# Altium schematic sheet ECU.SchDoc
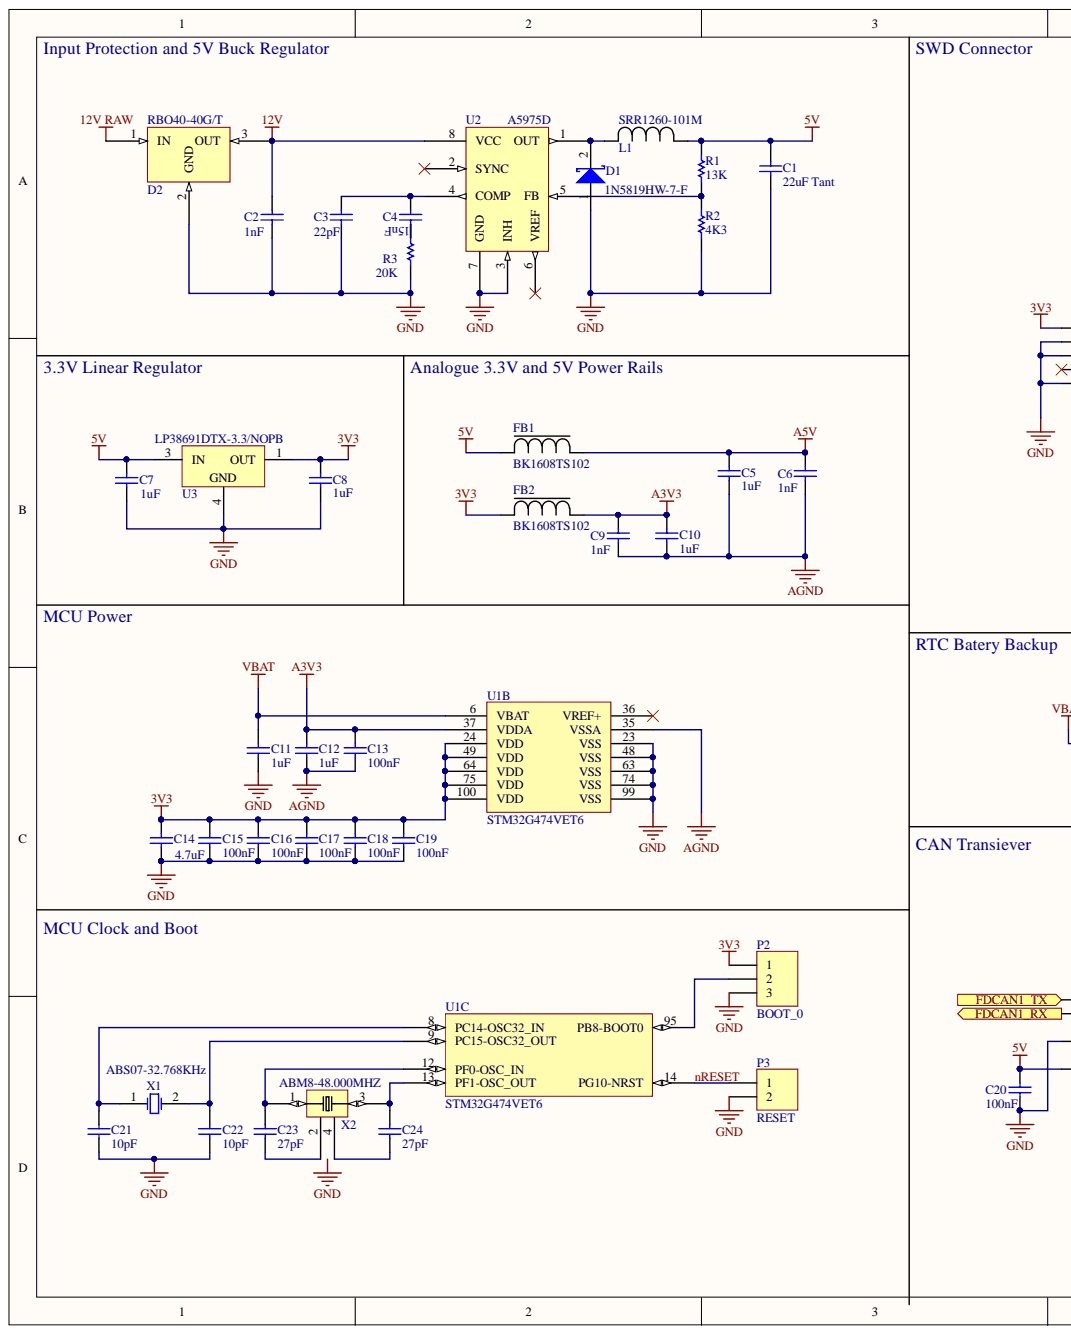
[diagram p0: ECU.SchDoc, left]
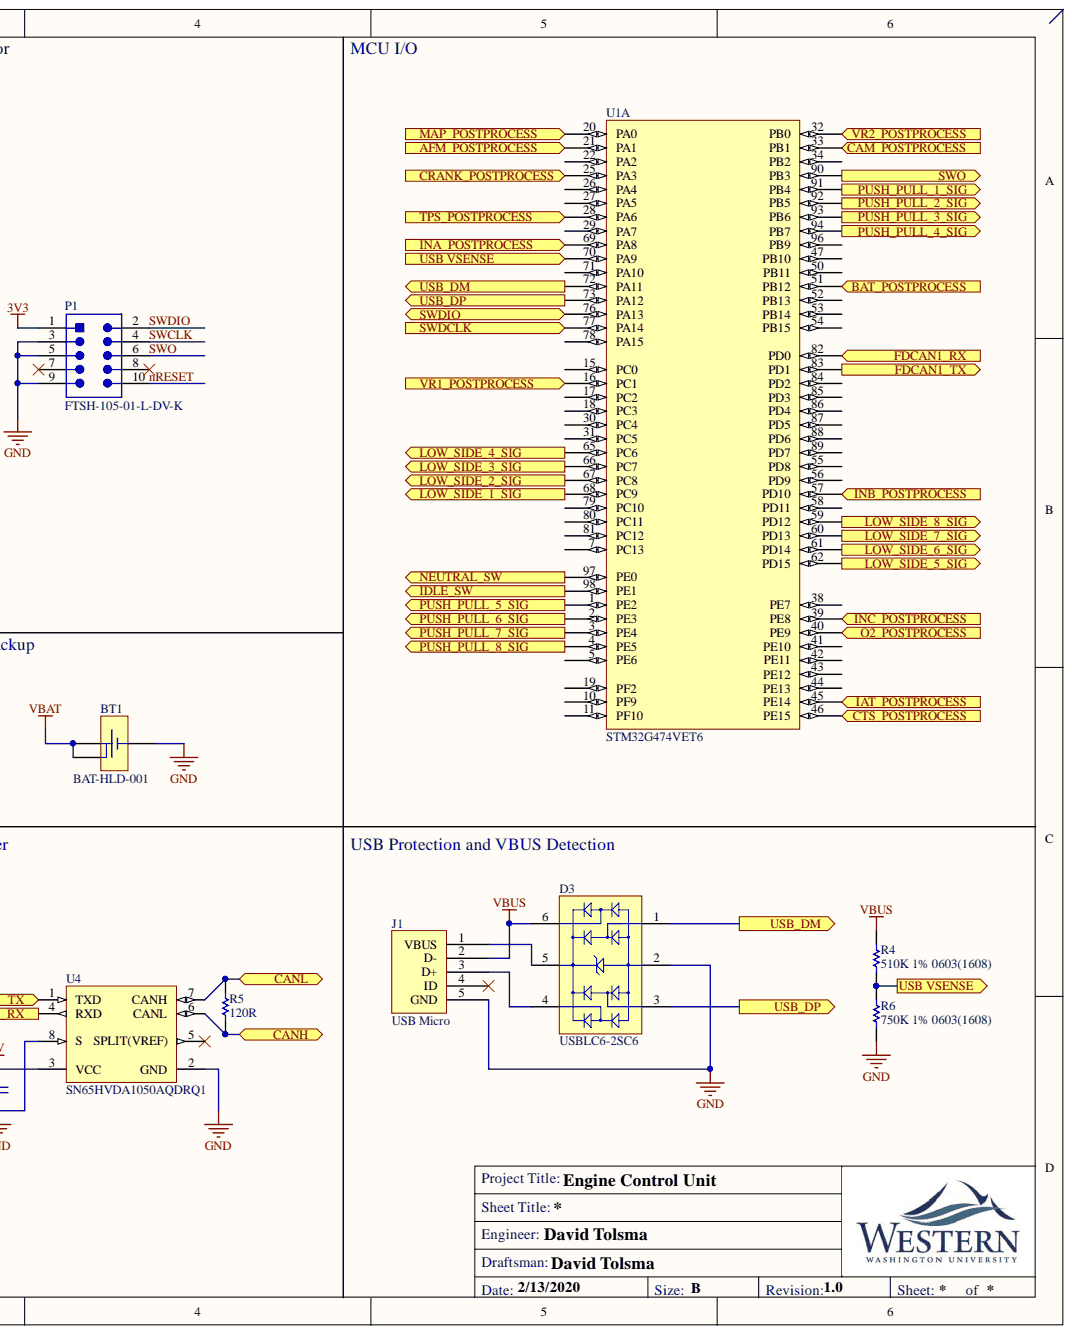
[diagram p1: ECU.SchDoc, right]

## Parts
BT1  BAT-HLD-001  [BATHLD-001]
C1  22uF Tant  value 22uF  [CAPC1210(3225)280_L]
C2  1nF  [CAPC0603(1608)100_N]
C3  22pF  [CAPC0603(1608)100_M]
C4  15nF  [CAPC0603(1608)100_L]
C5  1uF  [CAPC0603(1608)100_M]
C6  1nF  [CAPC0603(1608)100_N]
C7  1uF  [CAPC0603(1608)100_L]
C8  1uF  [CAPC0603(1608)100_L]
C9  1nF  [CAPC0603(1608)100_N]
C10  1uF  [CAPC0603(1608)100_M]
C11  1uF  [CAPC0603(1608)100_M]
C12  1uF  [CAPC0603(1608)100_M]
C13  100nF  [CAPC0603(1608)100_M]
C14  4.7uF  [CAPC0603(1608)55_N]
C15  100nF  [CAPC0603(1608)100_M]
C16  100nF  [CAPC0603(1608)100_M]
C17  100nF  [CAPC0603(1608)100_M]
C18  100nF  [CAPC0603(1608)100_M]
C19  100nF  [CAPC0603(1608)100_M]
C20  100nF  [CAPC0603(1608)100_M]
C21  10pF  [CAPC0603(1608)100_L]
C22  10pF  [CAPC0603(1608)100_L]
C23  27pF  [CAPC0603(1608)100_M]
C24  27pF  [CAPC0603(1608)100_M]
D1  1N5819HW-7-F  [DIOD-SOD-123-2_V]
D2  RBO40-40G/T  [D2PAK-L]
D3  USBLC6-2SC6  [STM-SOT23-6L_V]
FB1  BK1608TS102  [BK1608TS102-T]
FB2  BK1608TS102  [BK1608TS102-T]
J1  USB Micro  [USB_CONN]
L1  SRR1260-101M  [IND_BOURNS_SRR1260-101M]
P1  FTSH-105-01-L-DV-K  [SMTC-FTSH-105-01-L-DV-K_V]
P2  BOOT_0  [HDR1X3]
P3  RESET  [HDR1X2]
R1  13K  [RESC0603(1608)_L]
R2  4K3  [RESC0603(1608)_M]
R3  20K  [RESC0603(1608)_L]
R4  510K 1% 0603(1608)  [RESC0603(1608)_N]
R5  120R  [RESC0603(1608)_L]
R6  750K 1% 0603(1608)  [RESC0603(1608)_M]
U1  STM32G474VET6  [STM32G474VET6]  (parts A,B,C)
U2  A5975D  [A5975D_Medium_Density]
U3  LP38691DTX-3.3/NOPB  [TD03B_N]
U4  SN65HVDA1050AQDRQ1  [D8_L]
X1  ABS07-32.768KHz  [ABRA-ABS07-2_V]
X2  ABM8-48.000MHZ  [ABM8-48.000MHZ-10-1-U-T]

## Ports
AFM_POSTPROCESS, BAT_POSTPROCESS, CAM_POSTPROCESS, CANH, CANL, CRANK_POSTPROCESS, CTS_POSTPROCESS, FDCAN1_RX, FDCAN1_TX, IAT_POSTPROCESS, IDLE_SW, INA_POSTPROCESS, INB_POSTPROCESS, INC_POSTPROCESS, LOW_SIDE_1_SIG, LOW_SIDE_2_SIG, LOW_SIDE_3_SIG, LOW_SIDE_4_SIG, LOW_SIDE_5_SIG, LOW_SIDE_6_SIG, LOW_SIDE_7_SIG, LOW_SIDE_8_SIG, MAP_POSTPROCESS, NEUTRAL_SW, O2_POSTPROCESS, PUSH_PULL_1_SIG, PUSH_PULL_2_SIG, PUSH_PULL_3_SIG, PUSH_PULL_4_SIG, PUSH_PULL_5_SIG, PUSH_PULL_6_SIG, PUSH_PULL_7_SIG, PUSH_PULL_8_SIG, SWDCLK, SWDIO, SWO, TPS_POSTPROCESS, USB VSENSE, USB_DM, USB_DP, VR1_POSTPROCESS, VR2_POSTPROCESS

## Nets
3V3: C8.2 C14.2 C15.2 C16.2 C17.2 C18.2 C19.2 FB2.2 P1.1 P2.1 U1.24(VDD) U1.49(VDD) U1.64(VDD) U1.75(VDD) U1.100(VDD) U3.1
5V: C1.2 C7.2 C20.2 FB1.2 L1.1 R1.2 U3.3 U4.3(VCC)
12V: C2.1 D2.3 U2.8(VCC)
12V RAW: D2.1
A3V3: C9.1 C10.2 C12.2 C13.2 FB2.1 U1.37(VDDA)
A5V: C5.2 C6.1 FB1.1
AGND: C5.1 C6.2 C9.2 C10.1 C12.1 C13.1 U1.35(VSSA)
GND: BT1.1 C1.1 C2.2 C3.1 C7.1 C8.1 C11.1 C14.1 C15.1 C16.1 C17.1 C18.1 C19.1 C20.1 C21.1 C22.1 D1.1 D2.2 D3.2 J1.5(GND) P1.3 P1.5 P1.9 P2.3 P3.2 R2.2 R3.1 R6.1 U1.23(VSS) U1.48(VSS) U1.63(VSS) U1.74(VSS) U1.99(VSS) U2.3(INH) U2.7(GND) U3.4 U4.2(GND) U4.8(S)
VBAT: BT1.2 BT1.3 C11.2 U1.6(VBAT)
VBUS: D3.6 J1.2(D-) R4.2
AFM_POSTPROCESS: U1.21(PA1) ; port AFM_POSTPROCESS
BAT_POSTPROCESS: U1.51(PB12) ; port BAT_POSTPROCESS
CAM_POSTPROCESS: U1.33(PB1) ; port CAM_POSTPROCESS
CANH: R5.1 U4.6(CANL) ; port CANH
CANL: R5.2 U4.7(CANH) ; port CANL
CRANK_POSTPROCESS: U1.25(PA3) ; port CRANK_POSTPROCESS
CTS_POSTPROCESS: U1.46(PE15) ; port CTS_POSTPROCESS
FDCAN1_RX: U1.82(PD0) U4.4(RXD) ; port FDCAN1_RX
FDCAN1_TX: U1.83(PD1) U4.1(TXD) ; port FDCAN1_TX
IAT_POSTPROCESS: U1.45(PE14) ; port IAT_POSTPROCESS
IDLE_SW: U1.98(PE1) ; port IDLE_SW
INA_POSTPROCESS: U1.69(PA8) ; port INA_POSTPROCESS
INB_POSTPROCESS: U1.57(PD10) ; port INB_POSTPROCESS
INC_POSTPROCESS: U1.39(PE8) ; port INC_POSTPROCESS
LOW_SIDE_1_SIG: U1.68(PC9) ; port LOW_SIDE_1_SIG
LOW_SIDE_2_SIG: U1.67(PC8) ; port LOW_SIDE_2_SIG
LOW_SIDE_3_SIG: U1.66(PC7) ; port LOW_SIDE_3_SIG
LOW_SIDE_4_SIG: U1.65(PC6) ; port LOW_SIDE_4_SIG
LOW_SIDE_5_SIG: U1.62(PD15) ; port LOW_SIDE_5_SIG
LOW_SIDE_6_SIG: U1.61(PD14) ; port LOW_SIDE_6_SIG
LOW_SIDE_7_SIG: U1.60(PD13) ; port LOW_SIDE_7_SIG
LOW_SIDE_8_SIG: U1.59(PD12) ; port LOW_SIDE_8_SIG
MAP_POSTPROCESS: U1.20(PA0) ; port MAP_POSTPROCESS
NEUTRAL_SW: U1.97(PE0) ; port NEUTRAL_SW
nRESET: P1.10 P3.1 U1.14(PG10-NRST)
O2_POSTPROCESS: U1.40(PE9) ; port O2_POSTPROCESS
PUSH_PULL_1_SIG: U1.91(PB4) ; port PUSH_PULL_1_SIG
PUSH_PULL_2_SIG: U1.92(PB5) ; port PUSH_PULL_2_SIG
PUSH_PULL_3_SIG: U1.93(PB6) ; port PUSH_PULL_3_SIG
PUSH_PULL_4_SIG: U1.94(PB7) ; port PUSH_PULL_4_SIG
PUSH_PULL_5_SIG: U1.1(PE2) ; port PUSH_PULL_5_SIG
PUSH_PULL_6_SIG: U1.2(PE3) ; port PUSH_PULL_6_SIG
PUSH_PULL_7_SIG: U1.3(PE4) ; port PUSH_PULL_7_SIG
PUSH_PULL_8_SIG: U1.4(PE5) ; port PUSH_PULL_8_SIG
SWCLK: P1.4
SWDCLK: U1.77(PA14) ; port SWDCLK
SWDIO: P1.2
SWO: P1.6
TPS_POSTPROCESS: U1.28(PA6) ; port TPS_POSTPROCESS
USB VSENSE: R4.1 R6.2 U1.70(PA9) ; port USB VSENSE
USB_DM: D3.1 U1.72(PA11) ; port USB_DM
USB_DP: D3.3 U1.73(PA12) ; port USB_DP
VR1_POSTPROCESS: U1.16(PC1) ; port VR1_POSTPROCESS
VR2_POSTPROCESS: U1.32(PB0) ; port VR2_POSTPROCESS
NetC3_2: C3.2 C4.2 U2.4(COMP)
NetC4_1: C4.1 R3.2
NetC21_2: C21.2 U1.8(PC14-OSC32_IN) X1.1
NetC22_2: C22.2 U1.9(PC15-OSC32_OUT) X1.2
NetC23_1: C23.1 X2.2
NetC23_2: C23.2 U1.12(PF0-OSC_IN) X2.1
NetC24_1: C24.1 X2.4
NetC24_2: C24.2 U1.13(PF1-OSC_OUT) X2.3
NetD1_2: D1.2 L1.2 U2.1(OUT)
NetD3_4: D3.4 J1.3(D+)
NetD3_5: D3.5 J1.1(VBUS)
NetP2_2: P2.2 U1.95(PB8-BOOT0)
NetR1_1: R1.1 R2.1 U2.5(FB)
NetU1_76: U1.76(PA13) ; port SWDIO
NetU1_90: U1.90(PB3) ; port SWO

Not connected: J1 4(ID); P1 7 8; U1 5(PE6) 7(PC13) 10(PF9) 11(PF10) 15(PC0) 17(PC2) 18(PC3) 19(PF2) 22(PA2) 26(PA4) 27(PA5) 29(PA7) 30(PC4) 31(PC5) 34(PB2) 36(VREF+) 38(PE7) 41(PE10) 42(PE11) 43(PE12) 44(PE13) 47(PB10) 50(PB11) 52(PB13) 53(PB14) 54(PB15) 55(PD8) 56(PD9) 58(PD11) 71(PA10) 78(PA15) 79(PC10) 80(PC11) 81(PC12) 84(PD2) 85(PD3) 86(PD4) 87(PD5) 88(PD6) 89(PD7) 96(PB9); U2 2(SYNC) 6(VREF); U4 5(SPLIT(VREF))

## Library data of the parts
BT1 = CMP-0168-00043-1: 20mm Coin Cell Battery Holder; PCB_Layout: Complies with manufacturer's recommendation.; Component Kind: Standard; DatasheetVersion: rev1.0, Dec-2007; Library Name: Altium Content Vault; PackageDescription: Low Profile SM Battery Holder, 20mm Lithium Cell, 21.1 x 15.3 x 4.2 mm Body; PackageReference: BATHLD-001; PackageVersion: rev1.0, Dec-2007
C1 = CMP-1038-02268-1: CAP 22uF 10V ±10% 1210 (3225 Metric) Thickness 2.8mm SMD; Case-EIA: 1210; Case-Metric: 3225; Tolerance: Â±10%; Component Kind: Standard; Library Name: Altium Content Vault; Max Thickness: 2.8 mm; Rated Voltage: 10 V; Technology: SMT
C2 C6 C9 = CMP-1035-01887-1: CAP 1nF 50V ±2% 0603 (1608 Metric) Thickness 1mm SMD; Case-EIA: 0603; Case-Metric: 1608; Tolerance: Â±2%; Component Kind: Standard; Library Name: Altium Content Vault; Max Thickness: 1 mm; Rated Voltage: 50 V; Technology: SMT; Value: 1nF
C3 = CMP-1035-00822-1: CAP 22pF 50V ±10% 0603 (1608 Metric) Thickness 1mm SMD; Case-EIA: 0603; Case-Metric: 1608; Tolerance: Â±10%; Component Kind: Standard; Library Name: Altium Content Vault; Max Thickness: 1 mm; Rated Voltage: 50 V; Technology: SMT; Value: 22pF
C4 = CMP-1035-02380-1: CAP 15nF 50V ±5% 0603 (1608 Metric) Thickness 1mm SMD; Case-EIA: 0603; Case-Metric: 1608; Tolerance: Â±5%; Component Kind: Standard; Library Name: Altium Content Vault; Max Thickness: 1 mm; Rated Voltage: 50 V; Technology: SMT; Value: 15nF
C5 C10-C12 = CMP-1035-02733-1: CAP 1uF 10V ±10% 0603 (1608 Metric) Thickness 1mm SMD; Case-EIA: 0603; Case-Metric: 1608; Tolerance: Â±10%; Component Kind: Standard; Library Name: Altium Content Vault; Max Thickness: 1 mm; Rated Voltage: 10 V; Technology: SMT; Value: 1uF
C7 C8 = CMP-1035-02745-1: CAP 1uF 50V ±10% 0603 (1608 Metric) Thickness 1mm SMD; Case-EIA: 0603; Case-Metric: 1608; Tolerance: Â±10%; Component Kind: Standard; Library Name: Altium Content Vault; Max Thickness: 1 mm; Rated Voltage: 50 V; Technology: SMT; Value: 1uF
C13 C15-C20 = CMP-1035-02580-1: CAP 100nF 10V ±10% 0603 (1608 Metric) Thickness 1mm SMD; Case-EIA: 0603; Case-Metric: 1608; Tolerance: Â±10%; Component Kind: Standard; Library Name: Altium Content Vault; Max Thickness: 1 mm; Rated Voltage: 10 V; Technology: SMT; Value: 100nF
C14 = CMP-1035-02784-1: CAP 4.7uF 10V ±10% 0603 (1608 Metric) Thickness 0.55mm SMD; Case-EIA: 0603; Case-Metric: 1608; Tolerance: Â±10%; Component Kind: Standard; Library Name: Altium Content Vault; Max Thickness: 0.55 mm; Rated Voltage: 10 V; Technology: SMT; Value: 4.7uF
C21 C22 = CMP-1035-00556-1: CAP 10pF 50V ±1% 0603 (1608 Metric) Thickness 1mm SMD; Case-EIA: 0603; Case-Metric: 1608; Tolerance: Â±1%; Component Kind: Standard; Library Name: Altium Content Vault; Max Thickness: 1 mm; Rated Voltage: 50 V; Technology: SMT; Value: 10pF
C23 C24 = CMP-1035-00886-1: CAP 27pF 50V ±1% 0603 (1608 Metric) Thickness 1mm SMD; Case-EIA: 0603; Case-Metric: 1608; Tolerance: Â±1%; Component Kind: Standard; Library Name: Altium Content Vault; Max Thickness: 1 mm; Rated Voltage: 50 V; Technology: SMT; Value: 27pF
D1 = CMP-0303-00003-1: 1.0 A Surface Mount Schottky Barrier Rectifier, 40 V, -65 to 125 degC, 2-Pin SOD-123 Package, RoHS, Tape and Reel; Manufacturer: Diodes Inc; @ IF (A): 1; @ Terminal Temperature TT (degC): 90; @ VR (V): 40; Forward Voltage Drop VF (V): 0.45; Maximum Reverse Current IR (uA): 50; Peak Forward Surge Current IFSM (A): 25; VR (RMS) (V): 28; Component Kind: Standard; ComponentLink1Description: Manufacturer URL; ComponentLink1URL: http://www.diodes.com/; ComponentLink2Description: Datasheet; ComponentLink2URL: http://www.diodes.com/datasheets/ds30217.pdf; DatasheetVersion: Rev. 15-2; Library Name: Altium Content Vault; Maximum Average Rectified Current IO (A): 1; Mounting Technology: Surface Mount; Operating and Storage Temperature Range: -65 to 125 degC; PackageDescription: 2-Pin SOD-123, Body 2.7 x 1.55 mm, Pitch 3.7 mm; PackageReference: SOD-123-2; Packing: Tape and Reel; PartNumber: 1N5819HW-7-F; Peak Repetitive Reverse Voltage VRRM (V): 40; RoHS: TRUE; Total Capacitance CT (pF): 50
D2 = RBO40-40G/T: Designed to protect against battery reversal andload dump overvoltages in automotive applica-tions, this monolithic component offers multiplefunctions in the same package.; Component Kind: Standard; Library Name: ECU.SchLib
D3 = CMP-2000-05378-1: Very low capacitance ESD protection, 3.5 pF, -40 to 125 degC, 6-Pin SOT23, RoHS, Tape and Reel; Manufacturer: STMicroelectronics; Capacitance Value: 3.5pF; Clamping Voltage: 17V; Configuration: Dual; Direction Type: Uni-Directional; Leakage Current: 0.15uA; Number of Elements: 1; Peak Pulse Current: 5A; Product Depth (mm): 1.75mm; Product Height (mm): 1.3mm; Product Length (mm): 3.05mm; Rad Hardened: No; Reverse Breakdown Voltage: 6V; Reverse Stand-off Voltage: 5V; Suppressor Type: DiodeArrays; Test Current (It): 1mA; Clamping Voltage (Max): 17V; Component Kind: Standard; Datasheet URL: http://www.st.com/st-web-ui/static/active/en/resource/technical/document/datasheet/CD00050750.pdf; Datasheet Version: Rev. 5, 10/2011; Leakage Current (Max): 0.15uA; Library Name: Altium Content Vault; Manufacturer URL: http://www.st.com/; Mounting: SurfaceMount; Mounting Style: SurfaceMount; Operating Temperature Classification: Automotive; Operating Temperature Max Deg. C: 125C; Operating Temperature Min Deg. C: -40C; Operating Temp Range: -40Cto125C; Package / Case: SOT-23; Package Description: 6-Pin Small Outline Transistor, Body 2.925 x 1.625 mm, Pitch 0.95 mm; Package Reference: SOT23-6L; Packaging: TapeandReel; Pin Count: 6; Polarity: Uni-Directional; Working Voltage: 5V; Working Voltage (Max): 5V
J1 = USB MICRO CONN SMT R/A (Amphenol): Micro USB R/A SMT CONNECTOR; Component Kind: Standard; Supplier 1: Digi-Key; Supplier Part Number 1: 609-4618-1-ND; Library Name: WWUEE_SchematicLibrary.SchLib
L1 = SRR1260-101M: Built_By: EMA_UL_Team; Type: DEV; Vendor: Bourns Electronics; Component Kind: Standard; Description: SRR1260-101M; Library Name: ECU.SchLib; Manufacturer_Part_Number: SRR1260-101M; RefDes: RefDes; Value: Value; Copyright: Copyright (C) 2018 Accelerated Designs. All rights reserved
P1 = CMP-2000-05353-1: Male Header, Pitch 1.27 mm, 2 x 5 Position, Height 6.25 mm; Manufacturer: Samtec; Connector Type: Header,Unshrouded; Mating Products: SFMC-105-L2-S-D-TR-ND  -CONN.05"SURFACE10POSSMT  FLE-105-01-G-DV-TR-ND  -CONNSKT1.27MM10POSDUALSMD  FLE-105-01-G-DV-A-P-ND  -CONNSKT1.27MM10POSDUALSMD  FLE-105-01-G-DV-A-ND  -CONNSKT1.27MM10POSDUALSMD  CLP-105-02-G-D-P-TR-ND  -CONNRCPT10POSDUAL.05"SMD  SAM1155-05-ND  -CONNRCPT10POSDUAL.05"SMD  SAM1154-05-ND  -CONNRCPT10POSDUAL.05"SMD  SAM1153-05-ND  -CONNRCPT10POSDUAL.05"SMD  SAM1226-05-ND  -CONNRCPT.05"10POSDUALSMD; Number of Positions: 10; Number of Positions Loaded: All; Other Names: Q3937619; Pitch: 0.050"(1.27mm); Product Training Modules: Board-to-BoardConnectors; Category: Connectors,Interconnects; Color: Black; Component Kind: Standard; Contact Finish: Gold; Contact Finish Thickness: 10µin(0.25µm); Contact Mating Length: 0.120"(3.05mm); Contact Type: MalePin; Datasheet URL: http://suddendocs.samtec.com/prints/ftsh-1xx-xx-xxx-dv-xxx-mkt.pdf; Datasheet Version: Rev. ET, 10/1995; Family: RectangularConnectors-Headers,MalePins; Library Name: Altium Content Vault; Manufacturer URL: http://www.samtec.com/; Mounting Type: SurfaceMount; Number of Rows: 2; Package Description: Male Header, Pitch 1.27 mm, 2 x 5 Position, Height 5.97 mm, Tail Length 4.32 mm; Package Reference: FTSH-105-01-L-DV-K; Package URL: http://suddendocs.samtec.com/prints/ftsh-1xx-xx-xxx-dv-xxx-footprint.pdf; Packaging: Tube; Row Spacing: 0.050"(1.27mm); Series: FTSH; Standard Package: 1; Termination: Solder
P2 = Header 3: Header, 3-Pin; Component Kind: Standard; LatestRevisionDate: 17-Jul-2002; LatestRevisionNote: Re-released for DXP Platform.; Library Name: Miscellaneous Connectors.IntLib; Signal Integrity: Connector; Publisher: Altium Limited
P3 = Header 2: Header, 2-Pin; Component Kind: Standard; LatestRevisionDate: 17-Jul-2002; LatestRevisionNote: Re-released for DXP Platform.; Library Name: Miscellaneous Connectors.IntLib; Signal Integrity: Connector; Publisher: Altium Limited
R1 = CMP-1012-00635-1: 13K 0.1W 1% 0603 (1608 Metric)  SMD; Case-EIA: 0603; Case-Metric: 1608; Value: 13000.00 Ohm; Component Kind: Standard; Library Name: Altium Content Vault; Power: 0.1 W; Technology: SMT; Tolerance: 1 %
R2 = CMP-1012-00581-1: 4K3 0.1W 1% 0603 (1608 Metric)  SMD; Case-EIA: 0603; Case-Metric: 1608; Value: 4300.00 Ohm; Component Kind: Standard; Library Name: Altium Content Vault; Power: 0.1 W; Technology: SMT; Tolerance: 1 %
R3 = CMP-1012-00655-1: 20K 0.1W 1% 0603 (1608 Metric)  SMD; Case-EIA: 0603; Case-Metric: 1608; Value: 20000.00 Ohm; Component Kind: Standard; Library Name: Altium Content Vault; Power: 0.1 W; Technology: SMT; Tolerance: 1 %
R4 = CMP-1012-00816-1: 510K 0.1W 1% 0603 (1608 Metric)  SMD; Case-EIA: 0603; Case-Metric: 1608; Value: 510000.00 Ohm; Component Kind: Standard; Library Name: Altium Content Vault; Power: 0.1 W; Technology: SMT; Tolerance: 1 %
R5 = CMP-1012-00052-1: 120R 0.1W 5% 0603 (1608 Metric)  SMD; Case-EIA: 0603; Case-Metric: 1608; Value: 120.00 Ohm; Component Kind: Standard; Library Name: Altium Content Vault; Power: 0.1 W; Technology: SMT; Tolerance: 5 %
R6 = CMP-1012-00835-1: 750K 0.1W 1% 0603 (1608 Metric)  SMD; Case-EIA: 0603; Case-Metric: 1608; Value: 750000.00 Ohm; Component Kind: Standard; Library Name: Altium Content Vault; Power: 0.1 W; Technology: SMT; Tolerance: 1 %
U1 = STM32G474VET6_1: Component Kind: Standard; Description: STM32G474VET6; Library Name: ECU.SchLib
U2 = A5975D: The A5975D is a step-down monolithic power  switching regulator with a minimum switch current  limit of 3.75 A, it is therefore able to deliver up to 3  A DC current to the load depending on the  application conditions. The output voltage can be  set from 1.235 V to 35 V. The high current level is  also achieved thanks to a HSOP8 package with  exposed frame, that allows to reduce the RTHJ-A  down to approximately 40 °C/W. The device uses  an internal P-channel DMOS transistor (with a  typical RDS(on) of 250 mΩ) as switching element  to minimize the size of the external components.  An internal oscillator fixes the switching frequency  at 250 kHz. Having a minimum input voltage of  only 4 V, it fits automotive applications requiring  device operation even in cold crank conditions.  Pulse-by-pulse current limit with the internal  frequency modulation offers an effective constant  current short-circuit protection.; Component Kind: Standard; ComponentLink1Description: STMicro; ComponentLink1URL: https://www.st.com/en/power-management/a5975d.html#resource; Library Name: ECU.SchLib
U3 = CMP-0062-02610-1: 500mA Low Dropout CMOS Linear Regulators Stable with Ceramic Output Capacitors, 3-pin TO-252, 2500 Reel; Manufacturer: National Semiconductor; CompType: LDO IC; High-Reliability: Y; Code_JEDEC: TO-252-AA; Component Kind: Standard; ComponentLink1Description: Manufacturer URL; ComponentLink1URL: http://www.national.com/; ComponentLink2Description: LP38691 Datasheet; ComponentLink2URL: http://www.national.com/ds/LP/LP38691.pdf; ComponentLink3Description: LP38691 Web Page; ComponentLink3URL: http://www.national.com/pf/LP/LP38691.html; ComponentLink4Description: TD03B Package Page; ComponentLink4URL: http://www.national.com/packaging/folders/td03b.html; DatasheetVersion: Jun-2011; Library Name: Altium Content Vault; PackageDescription: TO252, Molded, Jedec, 6.8 x 6.10 x 2.30mm Body, 3 Lead, 2.28mm Pitch, Surface Mount; PackageReference: TD03B; PackageVersion: revD, Feb-2009; PartNumber: LP38691DTX-3.3/NOPB; PinCount: 3; PowerWise: Y; RoHS: Y
U4 = CMP-0315-00008-1: Automotive Catalog EMC-Optimized High-Speed CAN Transceiver, 70 mA, 5 V, -40 to 125 degC, 8-pin SOIC (D), Green (RoHS & no Sb/Br); Manufacturer: Texas Instruments; Bus Fault Voltage(V): -27 to 40; Drivers Per Package: 1; ICC(Max)(mA): 70; Supply Voltage(s)(V): 5; Transient Pulse Protection(V): -200 to 200; Code_JEDEC: MS-012AA; Component Kind: Standard; ComponentLink1Description: Datasheet; ComponentLink1URL: http://www.ti.com/general/docs/lit/getliterature.tsp?genericPartNumber=SN65HVDA1050A-Q1&fileType=pdf; DatasheetVersion: SLLS994A; Eco-Plan: Green (RoHS & no Sb/Br); Isolated: No; Library Name: Altium Content Vault; Mounting Technology: Surface Mount; PackageDescription: 8-Pin Plastic Small Outline Integrated Circuit, 4.9 x 3.9 mm, Pitch 1.27 mm Pitch; PackageReference: D8; PackageVersion: revM, Jun-2011; PartNumber: SN65HVDA1050AQDRQ1; Rating: Automotive; Receivers Per Package: 1; SubFamily: CAN
X1 = CMP-0447-00001-2: Crystal, 32.768 kHz, 12.5 pF, -40 to 85 degC, SMD Low Profile 3.2 x 1.5 x 0.9 mm, Tape and Reel; Manufacturer: Abracon; PartNumber: ABS07-32.768KHz-T; Drive Level (Type): 0.1 uW; Equivalent Series Resistance (R1): 70 Kohm; Frequency Tolerance @+25degC: +/- 20 ppm; Insulation Resistance (Min): 500 Mohm; Load Capacitance (CL): 12.5 pF; Operation Mode: Flexural Mode (Tuning Fork); Q Value (Type): 30000; Shunt Capacitance (C0): 0.9 to 1.2 pF; Component Kind: Standard; ComponentLink1Description: Manufacturer URL; ComponentLink1URL: http://www.abracon.com/; ComponentLink2Description: Datasheet; ComponentLink2URL: http://www.abracon.com/Resonators/ABS07.pdf; ComponentLink3Description: Package Specification; ComponentLink3URL: http://www.abracon.com/Resonators/ABS07.pdf; DatasheetVersion: 04.03.13; Frequency: 32.768 kHz; Library Name: Altium Content Vault; Mounting Technology: Surface Mount; Operating Temperature: -40 to 85 degC; PackageDescription: 2-Pin Hand Drawn, Body 3.2x1.5mm, Pitch 2.5mm; PackageReference: ABS07-2; Packing: Tape and Reel
X2 = ABM8-48.000MHZ-10-1-U-T: Component Kind: Standard; Description: ABM8-48.000MHZ-10-1-U-T; Library Name: ECU.SchLib
FB1 FB2 = BK1608TS102: Component Kind: Standard; Description: BK1608TS102; Library Name: ECU.SchLib
